# Altium schematic sheet UART-LA.SchDoc
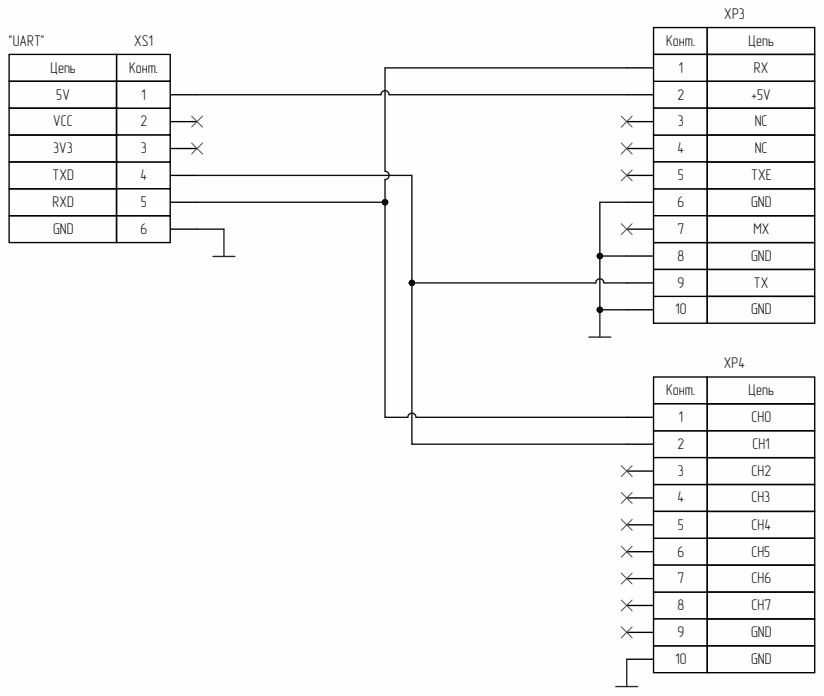
[diagram p0: UART-LA.SchDoc]

## Parts
XP3  Circuit1: RX  Circuit2: +5V  Circuit3: NC  Circuit5: TXE  Circuit6: GND  Circuit7: MX  Circuit9: TX  [IDC-10MS]  (hidden comment: IDC-10MS)
XP4  Circuit1: CH0  Circuit2: CH1  Circuit3: CH2  Circuit4: CH3  Circuit5: CH4  Circuit6: CH5  Circuit7: CH6  Circuit8: CH7  Circuit9: GND  [IDC-10MS]  (hidden comment: IDC-10MS)
XS1  Circuit1: 5V  Circuit2: VCC  Circuit3: 3V3  Circuit4: TXD  Circuit5: RXD  Circuit6: GND  Label: "UART"  [PBS-6R-left]  (hidden comment: PBS-6R)

## Nets
GND: XP3.6 XP3.8 XP3.10 XP4.10 XS1.6
NetXP3_1: XP3.1 XP4.1 XS1.5
NetXP3_2: XP3.2 XS1.1
NetXP3_9: XP3.9 XP4.2 XS1.4

Not connected: XP3 3 4 5 7; XP4 3 4 5 6 7 8 9; XS1 2 3

## Library data of the parts
XP3 XP4 = IDC-10MS: Box Header Pitch 2.54mm; Label: "Label"; Circuit10: GND; Component Kind: Standard; Library Name: Connectors.IntLib
  XP3: Circuit4: NC; Circuit8: GND
XS1 = PBS-6R: Female Header R/A type Pitch 2.54mm; Component Kind: Standard; Library Name: Connectors.IntLib
